# Altium schematic sheet ADC.SchDoc(ADC)
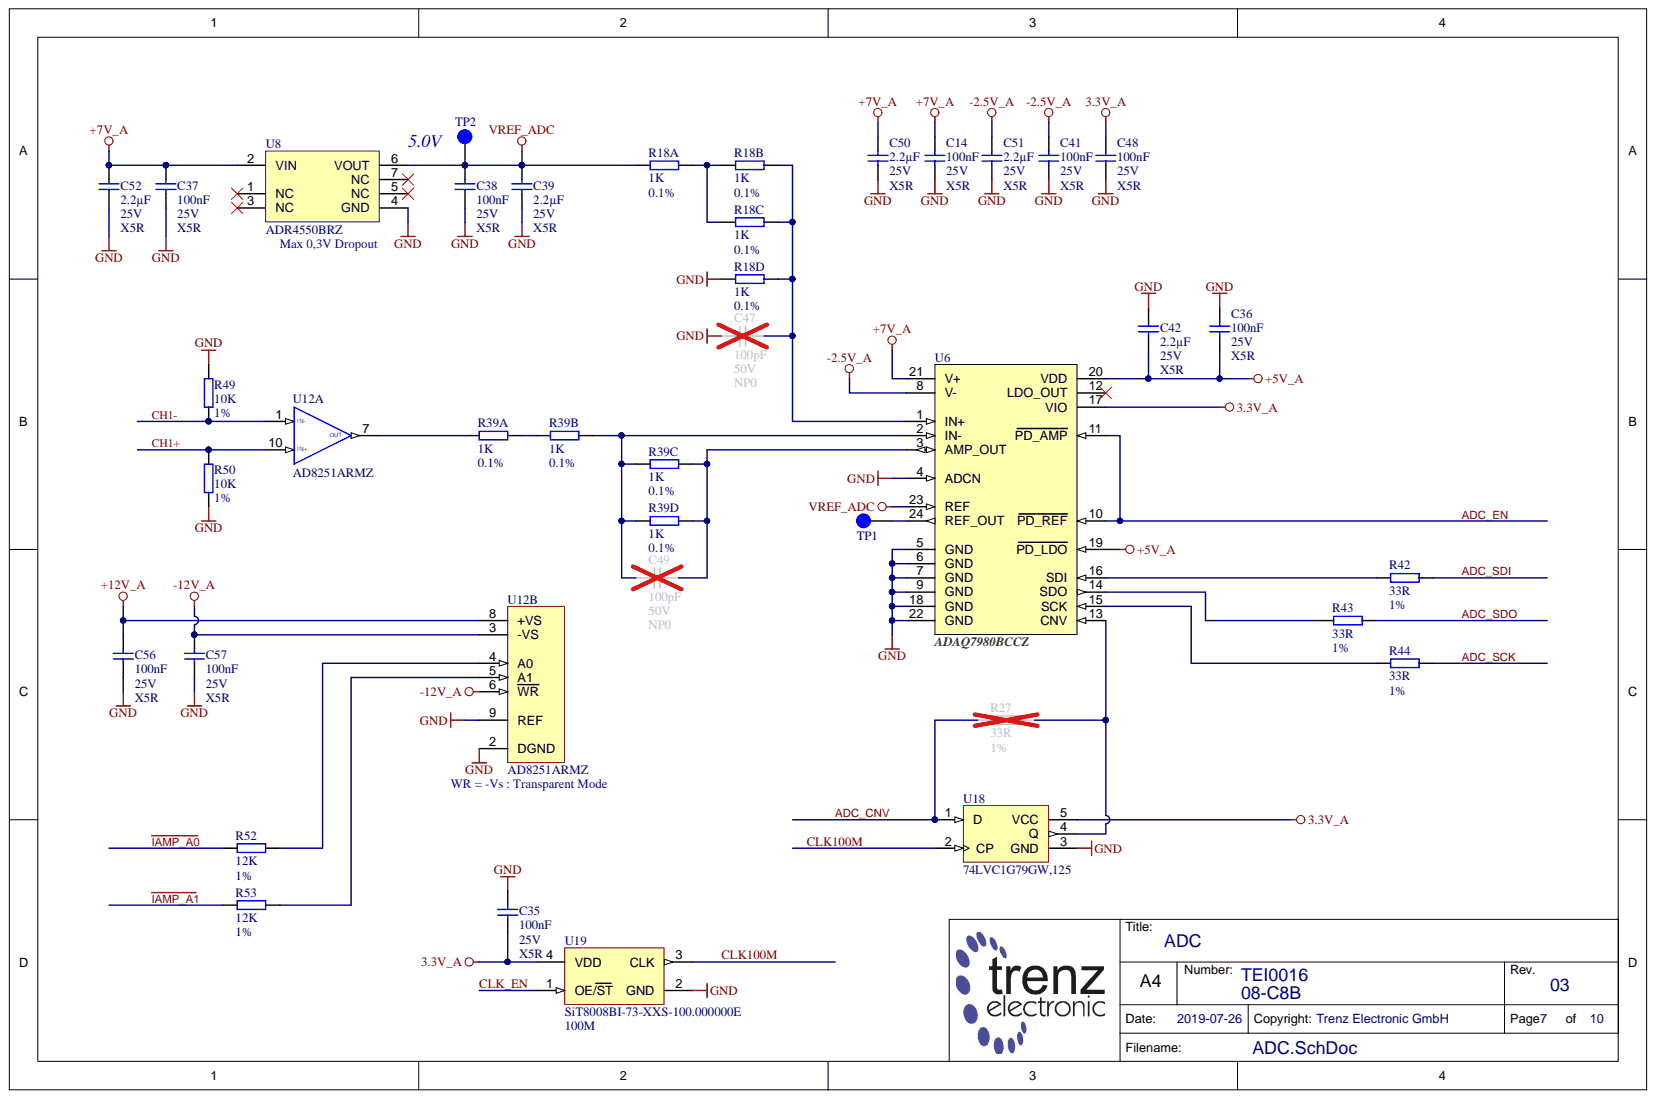
[diagram p0: ADC.SchDoc]

## Parts
C14  100nF  Technology: X5R  Voltage: 25V  [CAPC1005X55N]
C35  100nF  Voltage: 25V  [CAPC1005X55N]
C36  100nF  Technology: X5R  Voltage: 25V  [CAPC1005X55N]
C37  100nF  Technology: X5R  Voltage: 25V  [CAPC1005X55N]
C38  100nF  Technology: X5R  Voltage: 25V  [CAPC1005X55N]
C39  2.2µF  Technology: X5R  Voltage: 25V  [CAPC1608X90N]
C41  100nF  Technology: X5R  Voltage: 25V  [CAPC1005X55N]
C42  2.2µF  Technology: X5R  Voltage: 25V  [CAPC1608X90N]
C47  100pF  Voltage: 50V  [CAPC1005X55N]
C48  100nF  Technology: X5R  Voltage: 25V  [CAPC1005X55N]
C49  100pF  Voltage: 50V  [CAPC1005X55N]
C50  2.2µF  Technology: X5R  Voltage: 25V  [CAPC1608X90N]
C51  2.2µF  Technology: X5R  Voltage: 25V  [CAPC1608X90N]
C52  2.2µF  Technology: X5R  Voltage: 25V  [CAPC1608X90N]
C56  100nF  Technology: X5R  Voltage: 25V  [CAPC1005X55N]
C57  100nF  Technology: X5R  Voltage: 25V  [CAPC1005X55N]
R18  1K  Tolerance: 0.1%  [RESCAXS80P320X160X55-8N]  (parts A,B,C,D)
R27  33R  Tolerance: 1%  [RESC1005X40N]
R39  1K  Tolerance: 0.1%  [RESCAXS80P320X160X55-8N]  (parts A,B,C,D)
R42  33R  [RESC1005X40N]
R43  33R  Tolerance: 1%  [RESC1005X40N]
R44  33R  Tolerance: 1%  [RESC1005X40N]
R49  10K  Tolerance: 1%  [RESC1005X40N]
R50  10K  Tolerance: 1%  [RESC1005X40N]
R52  12K  [RESC1005X40N]
R53  12K  Tolerance: 1%  [RESC1005X40N]
TP1  Testpoint 0.8mm  [TP_0.8mm]  (value not drawn)
TP2  Testpoint 0.8mm  [TP_0.8mm]  (value not drawn)
U6  ADAQ7980BCCZ  [PQFN50P400X500X208-24N]
U6  ADAQ7980BCCZ  [PQFN50P400X500X208-24N]
U8  ADR4550BRZ  [SOIC127P600X175-8N]
U12  AD8251ARMZ  [SOP50P490X110-10N]  (parts A,B)
U18  74LVC1G79GW,125  [SOT65P210X110-5N]
U19  SiT8008BI-73-XXS-100.000000E  value 100M  Database Table Name: CLK  [2016]

## Nets
3.3V_A: C35.1 C48.1 U6.17 U18.5(VCC) U19.4(VDD)
+5V_A: C36.2 C42.2 U6.19 U6.20
+7V_A: C14.1 C37.1 C50.1 C52.1 U6.21 U8.2(VIN)
+12V_A: C56.1 U12.8(+VS)
-2.5V_A: C41.1 C51.1 U6.8
-12V_A: C57.1 U12.3(-VS) U12.6(WR)
GND: C14.2 C35.2 C36.1 C37.2 C38.2 C39.2 C41.2 C42.1 C47.1 C48.2 C50.2 C51.2 C52.2 C56.2 C57.2 R18.4 R49.1 R50.2 U6.4 U6.5 U6.6 U6.7 U6.9 U6.18 U6.22 U8.4(GND) U12.2(DGND) U12.9(REF) U18.3(GND) U19.2(GND)
VREF_ADC: C38.1 C39.1 R18.1 TP2.1 U6.23 U8.6(VOUT)
~{IAMP_A1}: R53.1
ADC_CNV: R27.2 U18.1(D)
ADC_EN: U6.10 U6.11
ADC_SCK: R44.2
ADC_SDI: R42.2
ADC_SDO: R43.2
CH1+: R50.1 U12.10(IN+)
CH1-: R49.2 U12.1(IN-)
CLK100M: U18.2(CP) U19.3(CLK)
CLK_EN: U19.1(OE/ST)
~{IAMP_A0}: R52.1
NetC47_2: C47.2 R18.5 R18.6 R18.7 U6.1
NetC49_1: C49.1 R39.3 R39.4 R39.7 U6.2
NetC49_2: C49.2 R39.5 R39.6 U6.3
NetR18_2: R18.2 R18.3 R18.8
NetR27_1: R27.1 U6.13 U18.4(Q)
NetR39_1: R39.1 U12.7(OUT)
NetR39_2: R39.2 R39.8
NetR42_1: R42.1 U6.16
NetR43_1: R43.1 U6.14
NetR44_1: R44.1 U6.15
NetR52_2: R52.2 U12.4(A0)
NetR53_2: R53.2 U12.5(A1)
NetTP1_1: TP1.1 U6.24

Not connected: U6 12; U8 1(NC) 3(NC) 5(NC) 7(NC)

## Library data of the parts
C14 C35-C38 C41 C48 C56 C57 = 22472: KON 100nF 25V X5R ±10% SMD BF 1005 (0402); Database Table Name: C_1005_0402; Mixed: SMT; Preferred: Yes; Temperature-Range: -55/+85; Value: 100n; AN: 22472; Case: 1005 (0402); Component Kind: Standard; Library Name: TE.DbLib
  C35: Technology: X5R
C39 C42 C50-C52 = 27910: KON 2.2uF 25V X5R ±10% SMD BF 1608 (0603); Database Table Name: C_1608_0603; Mixed: SMT; Temperature-Range: -55/+85; Value: 2.2u; AN: 27910; Case: 1608 (0603); Component Kind: Standard; Library Name: TE.DbLib; Tolerance: ±10%
C47 C49 = 23123: KON 100pF 50V NP0 5% SMD BF 1005 (0402); Database Table Name: C_1005_0402; Mixed: SMT; Preferred: Yes; Value: 100p; AN: 23123; Case: 1005 (0402); Component Kind: Standard; Library Name: TE.DbLib; Technology: NP0
R18 R39 = 29671: WID SMD Netz 4 fach 1K ±0.1%; Database Table Name: R_NET; H-ART: ACASA1001S1001P100; Mixed: SMT; Temperature-Range: -55/+125; AN: 29671; Component Kind: Standard; Library Name: TE.DbLib; Technology: TK25; Value: 1K
R27 R42-R44 = 22770: WID SMD 33R 1% 0,063W BF 1005 (0402); Database Table Name: R_1005_0402; H-ART: RC0402FR-0733RL; Mixed: SMT; Preferred: Yes; Value: 33; AN: 22770; Case: 1005 (0402); Component Kind: Standard; Library Name: TE.DbLib; Technology: TK100
  R42: Tolerance: 1%
R49 R50 = 22750: WID SMD 10K 1% 0,063W BF 1005 (0402); Database Table Name: R_1005_0402; H-ART: RC0402FR-0710KL; Mixed: SMT; Preferred: Yes; AN: 22750; Case: 1005 (0402); Component Kind: Standard; Library Name: TE.DbLib; Technology: TK100; Value: 10K
R52 R53 = 22598: WID SMD 12K 1% 0,063W  BF 1005 (0402); Database Table Name: R_1005_0402; Mixed: SMT; Preferred: Yes; AN: 22598; Case: 1005 (0402); Component Kind: Standard; Library Name: TE.DbLib; Technology: TK100; Value: 12K
  R52: Tolerance: 1%
TP1 TP2 = 26289: Database Table Name: PCB; Mixed: MECH; AN: 26289; Component Kind: Standard; Description: Testpoint 0.8mm; Library Name: TE.DbLib
U6 = 29866: Database Table Name: ADC; Temperature-Range: -55/+125; AN: 29866; Case: FLGA24; Component Kind: Standard; Description: ADAQ7980BCCZ; H-ART: ADAQ7980BCCZ; Library Name: TE.DbLib; Storage-Temperature: -65/+150(Abs); HelpURL: b:\AD\adlib\datasheet\ADAQ798x\
U6 = 29866: Database Table Name: ADC; Mixed: SMT; Temperature-Range: -55/+125; AN: 29866; Case: FLGA24; Component Kind: Standard; Description: ADAQ7980BCCZ; H-ART: ADAQ7980BCCZ; Library Name: TE.DbLib; Storage-Temperature: -65/+150(Abs); HelpURL: b:\AD\adlib\datasheet\ADAQ798x\
U8 = 28288: Database Table Name: REF; Mixed: SMT; Voltage: 5V; AN: 28288; Case: SO8; Component Kind: Standard; Description: ADR4550BRZ; H-ART: ADR4550BRZ; Library Name: TE.DbLib; HelpURL: b:\AD\adlib\datasheet\ADR45xx\
U12 = 24587: Database Table Name: OP; Mixed: SMT; Trenz-Stock: Yes; AN: 24587; Case: MSOP10; Component Kind: Standard; Description: AD8251ARMZ; H-ART: AD8251ARMZ; Library Name: TE.DbLib; HelpURL: B:\AD\adlib\datasheet\AD8251
U18 = 29689: Database Table Name: LOGIC; Mixed: SMT; Temperature-Range: -40/+125; AN: 29689; Case: SC70-5; Component Kind: Standard; Description: 74LVC1G79GW,125; H-ART: 74LVC1G79GW,125; Library Name: TE.DbLib; Storage-Temperature: -65/+150(Abs); HelpURL: b:\AD\adlib\datasheet\74LVC1G79\
U19 = 25961: SiT8008BI-73-XXS-100.000000E MEMS Oszillator 100MHz; Mixed: SMT; Preferred: Yes; AN: 25961; Case: 2.0  x 1.6mm; Component Kind: Standard; H-ART: SiT8008BI-73-XXS-100.000000E; Library Name: TE.DbLib; Trenz-Stock: Yes; HelpURL: B:\AD\adlib\datasheet\SiT8008
